# Altium schematic sheet Power.SchDoc
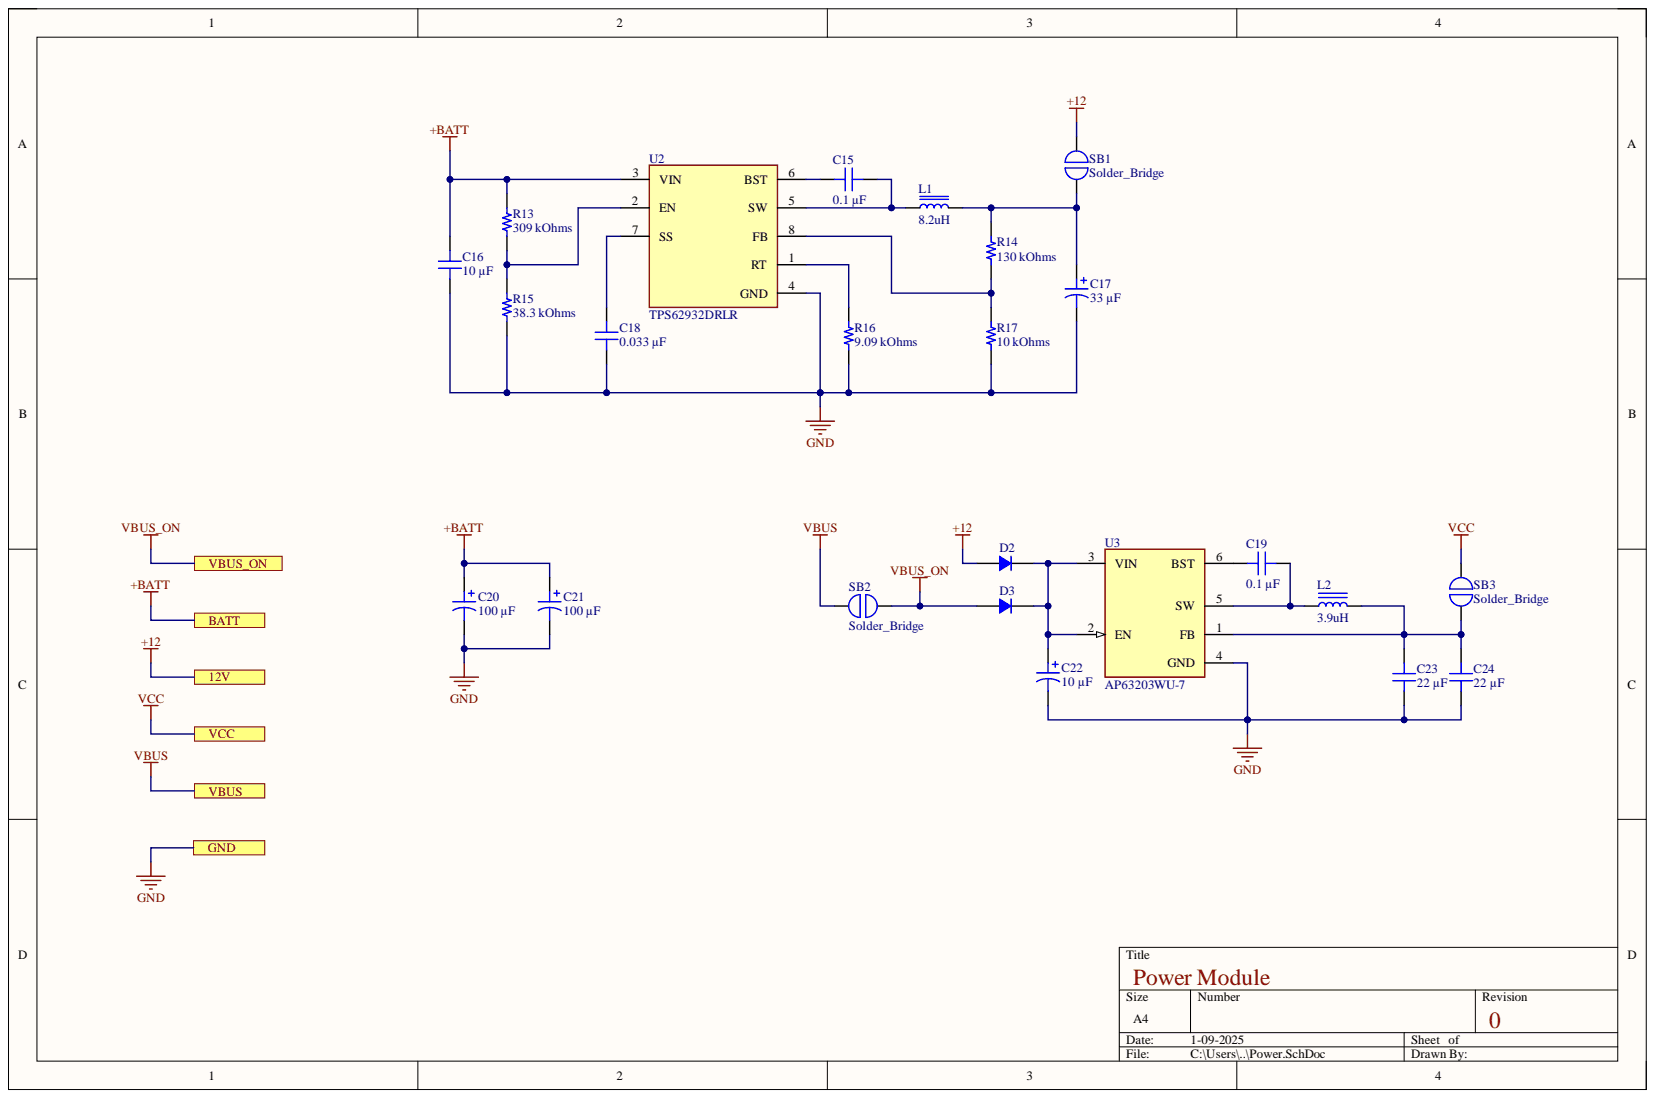
[diagram p0: Power.SchDoc]

## Parts
C15  0.1 µF  [CAP 0402_1005]
C16  10 µF  [CAP 1206_3216 - 0.8MM]
C17  33 µF  [CAP ALSMD 5.3MM H5.4MM]
C18  0.033 µF  [CAP 0402_1005]
C19  0.1 µF  [CAP 0201_0603]
C20  100 µF  [CAP ALSMD 6.6MM H8.0MM]
C21  100 µF  [CAP ALSMD 6.6MM H8.0MM]
C22  10 µF  [CAP ALSMD 4.3MM H5.4MM]
C23  22 µF  [MURATA GRM188 0603_1608]
C24  22 µF  [MURATA GRM188 0603_1608]
D2  RB160VAM-60TR  [RB160VAM-60TR-Footprint-1]  (value not drawn)
D3  RB160VAM-60TR  [RB160VAM-60TR-Footprint-1]  (value not drawn)
L1  8.2uH  [SRP5020TA-8R2M-Footprint-1]
L2  3.9uH  [SDR0302-3R9ML-Footprint-1]
R13  309 kOhms  [YAGEO RES 0201_0603]
R14  130 kOhms  [YAGEO RES 0201_0603]
R15  38.3 kOhms  [YAGEO RES 0201_0603]
R16  9.09 kOhms  [YAGEO RES 0201_0603]
R17  10 kOhms  [YAGEO RES 0201_0603]
SB1  Solder_Bridge  [Solder_Bridge-Footprint-1]  (no BOM)
SB2  Solder_Bridge  [Solder_Bridge-Footprint-1]  (no BOM)
SB3  Solder_Bridge  [Solder_Bridge-Footprint-1]  (no BOM)
U2  TPS62932DRLR  [FP-DRL0008A-MFG]
U3  AP63203WU-7  [FP-TSOT26-MFG]

## Ports
12V, BATT, GND, VBUS, VBUS_ON, VCC

## Nets
+12: D2.2 SB1.2 ; port 12V
+BATT: C16.2 C20.1 C21.1 R13.2 U2.3(VIN) ; port BATT
GND: C16.1 C17.2 C18.1 C20.2 C21.2 C22.2 C23.1 C24.1 R15.1 R16.1 R17.1 U2.4(GND) U3.4(GND) ; port GND
VBUS: SB2.1 ; port VBUS
VBUS_ON: D3.2 SB2.2 ; port VBUS_ON
VCC: SB3.2 ; port VCC
NetC15_1: C15.1 U2.6(BST)
NetC15_2: C15.2 L1.1 U2.5(SW)
NetC17_1: C17.1 L1.2 R14.2 SB1.1
NetC18_2: C18.2 U2.7(SS)
NetC19_1: C19.1 U3.6(BST)
NetC19_2: C19.2 L2.1 U3.5(SW)
NetC22_1: C22.1 D2.1 D3.1 U3.2(EN) U3.3(VIN)
NetC23_2: C23.2 C24.2 L2.2 SB3.1 U3.1(FB)
NetR13_1: R13.1 R15.2 U2.2(EN)
NetR14_1: R14.1 R17.2 U2.8(FB)
NetR16_2: R16.2 U2.1(RT)

## Library data of the parts
C15 = 885012205085: CAP CER 0.1UF 25V X7R 0402; manufacturer: Würth Elektronik; Database Table Name: Capacitors - Ceramic - 0402; Tolerance: ±10%; Applications: General Purpose; Capacitance: 0.1 µF; Component Kind: Standard; componentlink1description: Datasheet; componentlink1url: https://www.we-online.com/catalog/datasheet/885012205085.pdf; componentlink2description: DigiKey Link; componentlink2url: https://www.digikey.com/en/products/detail/würth-elektronik/885012205085/17829947; Device Package: 0402 (1005 Metric); lastupdated: 09-02-2024 20:04:00; Library Name: Celestial Altium Library - altium_library.DbLib; Lifecycle Status: Active; Minimum Order: 1; Mounting Type: Surface Mount, MLCC; Operating Temperature: -55°C ~ 125°C; Package / Case: 0402 (1005 Metric); packaging: TapeAndReel; Part Number: 885012205085; Part Status: Active; partid: 48192; price: 0.02; series: WCAP-CSGP; Size / Dimension: 0.039" L x 0.020" W (1.00mm x 0.50mm); Supplier 1: DigiKey; Supplier Part Number 1: 732-885012205085CT-ND; Temperature Coefficient: X7R; Thickness (Max): 0.022" (0.55mm); Value: 0.1 µF; Voltage - Rated: 25V
C16 = 885012108022: CAP CER 10UF 50V X5R 1206; manufacturer: Würth Elektronik; Database Table Name: Capacitors - Ceramic - 1206; Tolerance: ±10%; Applications: General Purpose; Capacitance: 10 µF; Component Kind: Standard; componentlink1description: Datasheet; componentlink1url: https://www.we-online.com/catalog/datasheet/885012108022.pdf; componentlink2description: DigiKey Link; componentlink2url: https://www.digikey.com/en/products/detail/würth-elektronik/885012108022/17829827; Device Package: 1206 (3216 Metric); lastupdated: 04-06-2024 19:10:08; Library Name: Celestial Altium Library - altium_library.DbLib; Lifecycle Status: Active; Minimum Order: 1; Mounting Type: Surface Mount, MLCC; Operating Temperature: -55°C ~ 85°C; Package / Case: 1206 (3216 Metric); packaging: TapeAndReel; Part Number: 885012108022; Part Status: Active; partid: 49462; price: 1.51; series: WCAP-CSGP; Size / Dimension: 0.126" L x 0.063" W (3.20mm x 1.60mm); Supplier 1: DigiKey; Supplier Part Number 1: 732-885012108022CT-ND; Temperature Coefficient: X5R; Thickness (Max): 0.071" (1.80mm); Value: 10 µF; Voltage - Rated: 50V
C17 = EEE-1CA330WAR: CAP ALUM 33UF 20% 16V SMD; manufacturer: Panasonic Electronic Components; Database Table Name: Capacitors - Aluminum - Electrolytic SMT; Lifetime @ Temp.: 1000 Hrs @ 85°C; Polarization: Polar; Ripple Current @ High Frequency: 59.5 mA @ 10 kHz; Ripple Current @ Low Frequency: 35 mA @ 120 Hz; Surface Mount Land Size: 0.209" L x 0.209" W (5.30mm x 5.30mm); Applications: Automotive; Capacitance: 33 µF; Component Kind: Standard; componentlink1description: Datasheet; componentlink1url: https://industrial.panasonic.com/cdbs/www-data/pdf/RDE0000/ABA0000C1142.pdf; componentlink2description: DigiKey Link; componentlink2url: https://www.digikey.com/en/products/detail/panasonic-electronic-components/EEE-1CA330WAR/1717750; Device Package: Radial, Can - SMD; Height - Seated (Max): 0.217" (5.50mm); lastupdated: 03-06-2024 19:53:13; Library Name: Celestial Altium Library - altium_library.DbLib; Lifecycle Status: Active; Minimum Order: 1; Mounting Type: Surface Mount; Operating Temperature: -40°C ~ 85°C; Package / Case: Radial, Can - SMD; packaging: TapeAndReel; Part Number: EEE-1CA330WAR; Part Status: Active; partid: 7848; price: 0.07; Ratings: AEC-Q200; series: S; Size / Dimension: 0.197" Dia (5.00mm); Supplier 1: DigiKey; Supplier Part Number 1: PCE4584CT-ND; Tolerance: ±20%; value: 33 µF; Voltage - Rated: 16 V
C18 = 885012205015: CAP CER 0.033UF 10V X7R 0402; manufacturer: Würth Elektronik; Database Table Name: Capacitors - Ceramic - 0402; Tolerance: ±10%; Applications: General Purpose; Capacitance: 0.033 µF; Component Kind: Standard; componentlink1description: Datasheet; componentlink1url: https://www.we-online.com/katalog/datasheet/885012205015.pdf; componentlink2description: DigiKey Link; componentlink2url: https://www.digikey.com/en/products/detail/würth-elektronik/885012205015/5453365; Device Package: 0402 (1005 Metric); lastupdated: 09-02-2024 20:04:15; Library Name: Celestial Altium Library - altium_library.DbLib; Lifecycle Status: Active; Minimum Order: 1; Mounting Type: Surface Mount, MLCC; Operating Temperature: -55°C ~ 125°C; Package / Case: 0402 (1005 Metric); packaging: TapeAndReel; Part Number: 885012205015; Part Status: Active; partid: 33714; price: 0.01; series: WCAP-CSGP; Size / Dimension: 0.039" L x 0.020" W (1.00mm x 0.50mm); Supplier 1: DigiKey; Supplier Part Number 1: 732-7510-1-ND; Temperature Coefficient: X7R; Thickness (Max): 0.022" (0.55mm); Value: 0.033 µF; Voltage - Rated: 10V
C19 = 885012104001: CAP CER 0.1UF 16V X5R 0201; manufacturer: Würth Elektronik; Database Table Name: Capacitors - Ceramic - 0201; Tolerance: ±10%; Applications: General Purpose; Capacitance: 0.1 µF; Component Kind: Standard; componentlink1description: Datasheet; componentlink1url: https://www.we-online.com/katalog/datasheet/885012104001.pdf; componentlink2description: DigiKey Link; componentlink2url: https://www.digikey.com/en/products/detail/würth-elektronik/885012104001/17734087; Device Package: 0201 (0603 Metric); lastupdated: 04-06-2024 19:00:59; Library Name: Celestial Altium Library - altium_library.DbLib; Lifecycle Status: Active; Minimum Order: 1; Mounting Type: Surface Mount, MLCC; Operating Temperature: -55°C ~ 85°C; Package / Case: 0201 (0603 Metric); packaging: TapeAndReel; Part Number: 885012104001; Part Status: Active; partid: 47476; price: 0.01; series: WCAP-CSGP; Size / Dimension: 0.024" L x 0.012" W (0.60mm x 0.30mm); Supplier 1: DigiKey; Supplier Part Number 1: 732-885012104001CT-ND; Temperature Coefficient: X5R; Thickness (Max): 0.013" (0.33mm); Value: 0.1 µF; Voltage - Rated: 16V
C20 C21 = EEE-FK1V101XP: CAP ALUM 100UF 20% 35V SMD; manufacturer: Panasonic Electronic Components; Database Table Name: Capacitors - Aluminum - Electrolytic SMT; Impedance: 340 mOhms; Lifetime @ Temp.: 2000 Hrs @ 105°C; Polarization: Polar; Ripple Current @ High Frequency: 280 mA @ 100 kHz; Ripple Current @ Low Frequency: 210 mA @ 120 Hz; Surface Mount Land Size: 0.260" L x 0.260" W (6.60mm x 6.60mm); Applications: Automotive; Capacitance: 100 µF; Component Kind: Standard; componentlink1description: Datasheet; componentlink1url: http://industrial.panasonic.com/www-cgi/jvcr13pz.cgi?E+PZ+3+ABA0120+EEEFK1V101XP+7+WW; componentlink2description: DigiKey Link; componentlink2url: https://www.digikey.com/en/products/detail/panasonic-electronic-components/EEE-FK1V101XP/765288; Device Package: Radial, Can - SMD; Height - Seated (Max): 0.315" (8.00mm); lastupdated: 03-06-2024 20:16:28; Library Name: Celestial Altium Library - altium_library.DbLib; Lifecycle Status: Active; Minimum Order: 1; Mounting Type: Surface Mount; Operating Temperature: -55°C ~ 105°C; Package / Case: Radial, Can - SMD; packaging: TapeAndReel; Part Number: EEE-FK1V101XP; Part Status: Active; partid: 27607; price: 0.13; Ratings: AEC-Q200; series: FK; Size / Dimension: 0.248" Dia (6.30mm); Supplier 1: DigiKey; Supplier Part Number 1: PCE3836CT-ND; Tolerance: ±20%; value: 100 µF; Voltage - Rated: 35 V
C22 = 865060340001: CAP ALUM 10UF 20% 16V SMD; manufacturer: Würth Elektronik; Database Table Name: Capacitors - Aluminum - Electrolytic SMT; Impedance: 1.35 Ohms; Lifetime @ Temp.: 2000 Hrs @ 105°C; Polarization: Polar; Ripple Current @ High Frequency: 90 mA @ 100 kHz; Ripple Current @ Low Frequency: 53.1 mA @ 120 Hz; Surface Mount Land Size: 0.169" L x 0.169" W (4.30mm x 4.30mm); Applications: General Purpose; Capacitance: 10 µF; Component Kind: Standard; componentlink1description: Datasheet; componentlink1url: https://katalog.we-online.de/pbs/datasheet/865060340001.pdf; componentlink2description: DigiKey Link; componentlink2url: https://www.digikey.com/en/products/detail/würth-elektronik/865060340001/5728113; Device Package: Radial, Can - SMD; Height - Seated (Max): 0.217" (5.50mm); lastupdated: 03-06-2024 19:52:57; Library Name: Celestial Altium Library - altium_library.DbLib; Lifecycle Status: Active; Minimum Order: 1; Mounting Type: Surface Mount; Operating Temperature: -55°C ~ 105°C; Package / Case: Radial, Can - SMD; packaging: TapeAndReel; Part Number: 865060340001; Part Status: Active; partid: 7403; price: 0.11; series: WCAP-ASLL; Size / Dimension: 0.157" Dia (4.00mm); Supplier 1: DigiKey; Supplier Part Number 1: 732-8518-1-ND; Tolerance: ±20%; value: 10 µF; Voltage - Rated: 16 V
C23 C24 = GRM188R60J226MEA0D: CAP CER 22UF 6.3V X5R 0603; manufacturer: Murata Electronics; Database Table Name: Capacitors - Ceramic - 0603; Tolerance: ±20%; Applications: General Purpose; Capacitance: 22 µF; Component Kind: Standard; componentlink1description: Datasheet; componentlink1url: https://www.murata.com/~/media/webrenewal/support/library/catalog/products/capacitor/mlcc/c02e.ashx?la=en-us; componentlink2description: DigiKey Link; componentlink2url: https://www.digikey.com/en/products/detail/murata-electronics/GRM188R60J226MEA0D/4280542; Device Package: 0603 (1608 Metric); lastupdated: 20-07-2024 00:23:20; Library Name: Celestial Altium Library - altium_library.DbLib; Lifecycle Status: Active; Minimum Order: 1; Mounting Type: Surface Mount, MLCC; Operating Temperature: -55°C ~ 85°C; Package / Case: 0603 (1608 Metric); packaging: TapeAndReel; Part Number: GRM188R60J226MEA0D; Part Status: Active; partid: 17945; price: 0.02; series: GRM; Size / Dimension: 0.063" L x 0.031" W (1.60mm x 0.80mm); Supplier 1: DigiKey; Supplier Part Number 1: 490-7611-1-ND; Temperature Coefficient: X5R; Thickness (Max): 0.039" (1.00mm); Value: 22 µF; Voltage - Rated: 6.3V
D2 D3 = CMP-00035-1: DIODE SCHOTTKY 60V 1A TUMD2M; Max Reverse Current: 40uA; Peak Non-Repetitive Surge Current: 3A; Average Rectified Current: 1A; Case/Package: SMD/SMT; Component Kind: Standard; Forward Current: 1A; Lead Free: Lead Free; Library Name: CSD Robocon Workspace; Max Repetitive Reverse Voltage (Vrrm): 60V; Max Reverse Voltage (DC): 60V; Mounting Technology: Surface Mount; Packaging: Cut Tape; Pins: 2; RoHS Compliant: Yes; Series: -
L1 = CMP-00050-1: Ind Chip Power Shielded Wirewound 8.2uH 20% 100KHz 21Q-Factor Metal 2.3A T/R; DC Resistance (DCR): 171mR; Saturation Current: 3.5A; Self Resonant Frequency: 20MHz; Case/Package: Nonstandard; Component Kind: Standard; Core Material: Metal; Library Name: CSD Robocon Workspace; Max Operating Temperature: 105°C; Min Operating Temperature: -40°C; Mounting Technology: Surface Mount; Packaging: Cut Tape; Ratings: AEC-Q200; Series: SRP5020TA; Shielding: Shielded; Test Frequency: 100kHz; Tolerance: 20%; Value: 8.2uH
L2 = CMP-00048-1: Inductor Power Wirewound 3.9uH 20% 1KHz 24Q-Factor Ferrite 1.3A 120mOhm DCR T/R; DC Resistance (DCR): 120mR; Q Factor: 24; Saturation Current: 1.5A; Self Resonant Frequency: 50MHz; Shielding: Unshielded; Case/Package: Nonstandard; Component Kind: Standard; Core Material: Ferrite; DC Current: 1.3A; Depth: 3.1mm; Height: 2.5mm; Inductance Tolerance: 20%; Length: 3mm; Library Name: CSD Robocon Workspace; Max DC Current: 1.3A; Max Operating Temperature: 125°C; Military Standard: Not; Min Operating Temperature: -40°C; Mounting Technology: Surface Mount; Package Quantity: 2000; Packaging: Tape and Reel; Radiation Hardening: No; Reach SVHC Compliant: No; RMS Current (Irms): 1.3A; RoHS Compliant: Yes; Series: SDR0302; Termination: SMD/SMT; Test Frequency: 1kHz; Tolerance: 20%; Value: 3.9uH; Width: 2.5mm
R13 = RC0201FR-07309KL: RES 309K OHM 1% 1/20W 0201; Manufacturer: Yageo; Database Table Name: Resistors - Surface Mount - 0201; Power (Watts): 0.05W, 1/20W; Temperature Coefficient: ±200ppm/°C; Component Kind: Standard; ComponentLink1Description: Datasheet; ComponentLink1URL: https://www.yageo.com/upload/media/product/productsearch/datasheet/rchip/PYu-RC_Group_51_RoHS_L_10.pdf; ComponentLink2Description: DigiKey Link; ComponentLink2URL: https://www.digikey.com/en/products/detail/yageo/RC0201FR-07309KL/5280448; Composition: Thick Film; Device Package: 0201 (0603 Metric); Features: Moisture Resistant; Height - Seated (Max): 0.010" (0.26mm); LastUpdated: 25-07-2024 09:16:27; Library Name: Celestial Altium Library - altium_library.DbLib; Lifecycle Status: Active; Minimum Order: 1; Number of Terminations: 2; Operating Temperature: -55°C ~ 125°C; Package / Case: 0201 (0603 Metric); Packaging: CutTape; Part Number: RC0201FR-07309KL; Part Status: Active; PartId: 1082; Price: 0.01; Resistance: 309 kOhms; Series: RC_L; Size / Dimension: 0.024" L x 0.012" W (0.60mm x 0.30mm); Supplier 1: DigiKey; Supplier Device Package: 0201; Supplier Part Number 1: YAG2588CT-ND; Tolerance: ±1%; Value: 309 kOhms
R14 = RC0201JR-07130KL: RES 130K OHM 5% 1/20W 0201; Manufacturer: Yageo; Database Table Name: Resistors - Surface Mount - 0201; Power (Watts): 0.05W, 1/20W; Temperature Coefficient: ±200ppm/°C; Component Kind: Standard; ComponentLink1Description: Datasheet; ComponentLink1URL: https://www.yageo.com/upload/media/product/productsearch/datasheet/rchip/PYu-RC_Group_51_RoHS_L_10.pdf; ComponentLink2Description: DigiKey Link; ComponentLink2URL: https://www.digikey.com/en/products/detail/yageo/RC0201JR-07130KL/5280722; Composition: Thick Film; Device Package: 0201 (0603 Metric); Features: Moisture Resistant; Height - Seated (Max): 0.010" (0.26mm); LastUpdated: 25-07-2024 09:16:22; Library Name: Celestial Altium Library - altium_library.DbLib; Lifecycle Status: Active; Minimum Order: 1; Number of Terminations: 2; Operating Temperature: -55°C ~ 125°C; Package / Case: 0201 (0603 Metric); Packaging: CutTape; Part Number: RC0201JR-07130KL; Part Status: Active; PartId: 4536; Price: 0.01; Resistance: 130 kOhms; Series: RC_L; Size / Dimension: 0.024" L x 0.012" W (0.60mm x 0.30mm); Supplier 1: DigiKey; Supplier Device Package: 0201; Supplier Part Number 1: YAG2862CT-ND; Tolerance: ±5%; Value: 130 kOhms
R15 = RC0201FR-0738K3L: RES 38.3K OHM 1% 1/20W 0201; Manufacturer: Yageo; Database Table Name: Resistors - Surface Mount - 0201; Power (Watts): 0.05W, 1/20W; Temperature Coefficient: ±200ppm/°C; Component Kind: Standard; ComponentLink1Description: Datasheet; ComponentLink1URL: https://www.yageo.com/upload/media/product/productsearch/datasheet/rchip/PYu-RC_Group_51_RoHS_L_10.pdf; ComponentLink2Description: DigiKey Link; ComponentLink2URL: https://www.digikey.com/en/products/detail/yageo/RC0201FR-0738K3L/5280495; Composition: Thick Film; Device Package: 0201 (0603 Metric); Features: Moisture Resistant; Height - Seated (Max): 0.010" (0.26mm); LastUpdated: 25-07-2024 09:16:26; Library Name: Celestial Altium Library - altium_library.DbLib; Lifecycle Status: Active; Minimum Order: 1; Number of Terminations: 2; Operating Temperature: -55°C ~ 125°C; Package / Case: 0201 (0603 Metric); Packaging: TapeAndReel; Part Number: RC0201FR-0738K3L; Part Status: Active; PartId: 1416; Price: 0.01; Resistance: 38.3 kOhms; Series: RC_L; Size / Dimension: 0.024" L x 0.012" W (0.60mm x 0.30mm); Supplier 1: DigiKey; Supplier Device Package: 0201; Supplier Part Number 1: YAG2635CT-ND; Tolerance: ±1%; Value: 38.3 kOhms
R16 = RC0201FR-079K09L: RES 9.09K OHM 1% 1/20W 0201; Manufacturer: Yageo; Database Table Name: Resistors - Surface Mount - 0201; Power (Watts): 0.05W, 1/20W; Temperature Coefficient: ±200ppm/°C; Component Kind: Standard; ComponentLink1Description: Datasheet; ComponentLink1URL: https://www.yageo.com/upload/media/product/productsearch/datasheet/rchip/PYu-RC_Group_51_RoHS_L_10.pdf; ComponentLink2Description: DigiKey Link; ComponentLink2URL: https://www.digikey.com/en/products/detail/yageo/RC0201FR-079K09L/5280716; Composition: Thick Film; Device Package: 0201 (0603 Metric); Features: Moisture Resistant; Height - Seated (Max): 0.010" (0.26mm); LastUpdated: 25-07-2024 09:16:28; Library Name: Celestial Altium Library - altium_library.DbLib; Lifecycle Status: Active; Minimum Order: 1; Number of Terminations: 2; Operating Temperature: -55°C ~ 125°C; Package / Case: 0201 (0603 Metric); Packaging: TapeAndReel; Part Number: RC0201FR-079K09L; Part Status: Active; PartId: 391; Price: 0.01; Resistance: 9.09 kOhms; Series: RC_L; Size / Dimension: 0.024" L x 0.012" W (0.60mm x 0.30mm); Supplier 1: DigiKey; Supplier Device Package: 0201; Supplier Part Number 1: YAG2856CT-ND; Tolerance: ±1%; Value: 9.09 kOhms
R17 = RC0201FR-0710KL: RES 10K OHM 1% 1/20W 0201; Manufacturer: Yageo; Database Table Name: Resistors - Surface Mount - 0201; Power (Watts): 0.05W, 1/20W; Temperature Coefficient: ±200ppm/°C; Component Kind: Standard; ComponentLink1Description: Datasheet; ComponentLink1URL: https://www.yageo.com/upload/media/product/productsearch/datasheet/rchip/PYu-RC_Group_51_RoHS_L_10.pdf; ComponentLink2Description: DigiKey Link; ComponentLink2URL: https://www.digikey.com/en/products/detail/yageo/RC0201FR-0710KL/1948870; Composition: Thick Film; Device Package: 0201 (0603 Metric); Features: Moisture Resistant; Height - Seated (Max): 0.010" (0.26mm); LastUpdated: 25-07-2024 09:16:23; Library Name: Celestial Altium Library - altium_library.DbLib; Lifecycle Status: Active; Minimum Order: 1; Number of Terminations: 2; Operating Temperature: -55°C ~ 125°C; Package / Case: 0201 (0603 Metric); Packaging: TapeAndReel; Part Number: RC0201FR-0710KL; Part Status: Active; PartId: 2281; Price: 0.01; Resistance: 10 kOhms; Series: RC_L; Size / Dimension: 0.024" L x 0.012" W (0.60mm x 0.30mm); Supplier 1: DigiKey; Supplier Device Package: 0201; Supplier Part Number 1: 311-10.0KMCT-ND; Tolerance: ±1%; Value: 10 kOhms
SB1-SB3 = CMP-00024-5: Solder bridge component; Library Name: CSD Robocon Workspace
U2 = CMP-00049-2: 3.8-V to 30-V input, 2-A, 200-kHz to 2.2-MHz, low-IQ synchronous buck converter in SOT-583 package 8-SOT-5X3 -40 to 150; Component Kind: Standard; Library Name: CSD Robocon Workspace
U3 = CMP-00034-2: AP63203 Series 3.3 V 2 A SMT Fixed Low IQ Synchronous Buck - TSOT-26; Component Kind: Standard; Library Name: CSD Robocon Workspace
